# Altium schematic sheet Sch-QWA60_40 Pin GPIO.SchDoc
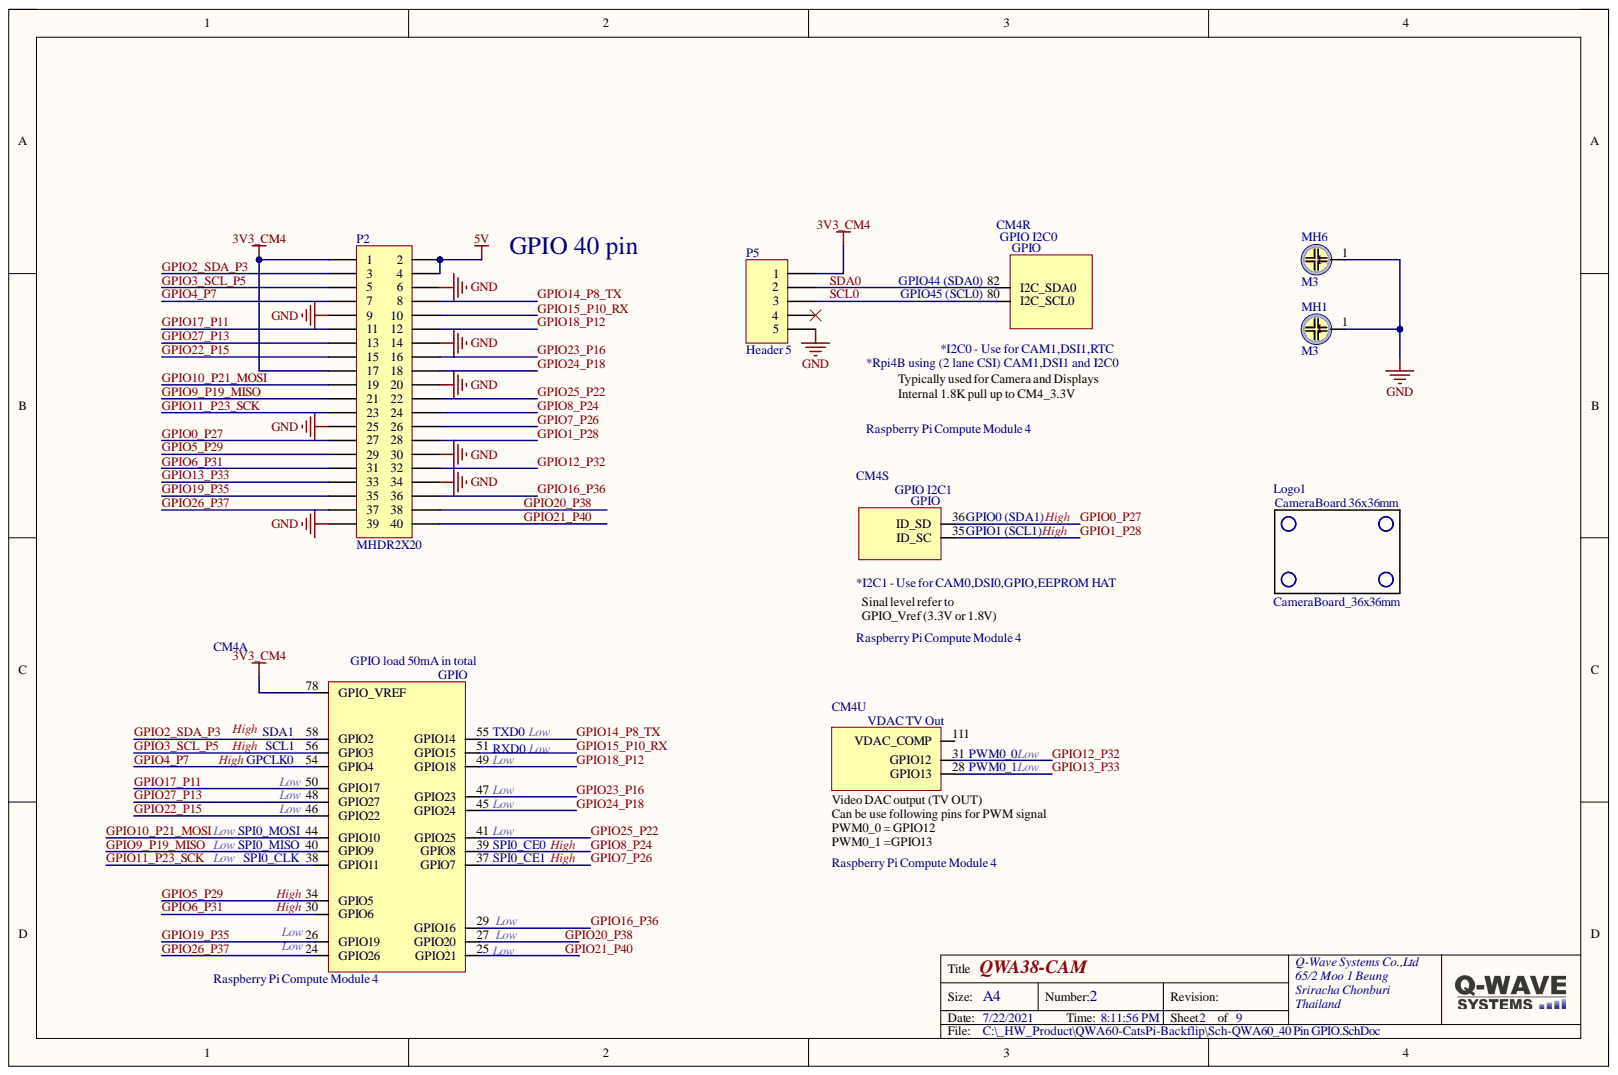
[diagram p0: Sch-QWA60_40 Pin GPIO.SchDoc]

## Parts
CM4  Raspberry Pi Compute Module 4  [CM4-F40C-100DS-0.4v_1.5mm_0mm]  (parts A,R,S,U)
Logo1  CameraBoard_36x36mm  [Qwave_Logo1]
MH1  M3  [MH3-4]
MH6  M3  [MH3-4]
P2  MHDR2X20  [HDR2X20_2.54_180 - 2 Hole]
P5  Header 5  [HDR1X5]

## Nets
3V3_CM4: CM4.78(GPIO_VREF) P2.1 P2.17 P5.1
5V: P2.2 P2.4
GND: MH1.1 MH6.1 P2.6 P2.9 P2.14 P2.20 P2.25 P2.30 P2.34 P2.39 P5.5
GPIO0_P27: CM4.36 P2.27
GPIO1_P28: CM4.35 P2.28
GPIO2_SDA_P3: CM4.58(GPIO2) P2.3
GPIO3_SCL_P5: CM4.56(GPIO3) P2.5
GPIO4_P7: CM4.54(GPIO4) P2.7
GPIO5_P29: CM4.34(GPIO5) P2.29
GPIO6_P31: CM4.30(GPIO6) P2.31
GPIO7_P26: CM4.37(GPIO7) P2.26
GPIO8_P24: CM4.39(GPIO8) P2.24
GPIO9_P19_MISO: CM4.40(GPIO9) P2.21
GPIO10_P21_MOSI: CM4.44(GPIO10) P2.19
GPIO11_P23_SCK: CM4.38(GPIO11) P2.23
GPIO12_P32: CM4.31(GPIO12) P2.32
GPIO13_P33: CM4.28(GPIO13) P2.33
GPIO14_P8_TX: CM4.55(GPIO14) P2.8
GPIO15_P10_RX: CM4.51(GPIO15) P2.10
GPIO16_P36: CM4.29(GPIO16) P2.36
GPIO17_P11: CM4.50(GPIO17) P2.11
GPIO18_P12: CM4.49(GPIO18) P2.12
GPIO19_P35: CM4.26(GPIO19) P2.35
GPIO20_P38: CM4.27(GPIO20) P2.38
GPIO21_P40: CM4.25(GPIO21) P2.40
GPIO22_P15: CM4.46(GPIO22) P2.15
GPIO23_P16: CM4.47(GPIO23) P2.16
GPIO24_P18: CM4.45(GPIO24) P2.18
GPIO25_P22: CM4.41(GPIO25) P2.22
GPIO26_P37: CM4.24(GPIO26) P2.37
GPIO27_P13: CM4.48(GPIO27) P2.13
SCL0: CM4.80 P5.3
SDA0: CM4.82 P5.2

Not connected: CM4 111(VDAC_COMP); P5 4

## Library data of the parts
CM4 = CM4: Raspberry Pi Compute Module 4 by Amornthep Phunsin; Component Kind: Standard; Library Name: CM4.SchLib
MH1 MH6 = HOLD: Component Kind: Standard; Library Name: Mechanical.SchLib
P2 = MHDR2X20: Header, 20-Pin, Dual row; Component Kind: Standard; LatestRevisionDate: 17-Jul-2002; LatestRevisionNote: Re-released for DXP Platform.; Library Name: Miscellaneous Connectors.IntLib; Signal Integrity: Connector; Publisher: Altium Limited
P5 = Header 5: Header, 5-Pin; Component Kind: Standard; LatestRevisionDate: 17-Jul-2002; LatestRevisionNote: Re-released for DXP Platform.; Library Name: Miscellaneous Connectors.IntLib; Signal Integrity: Connector; Publisher: Altium Limited
Logo1 = CameraBoard_36x36mm: Component Kind: Standard; Library Name: QwaveLib.SchLib
